# Altium schematic sheet INTERFACE.SchDoc
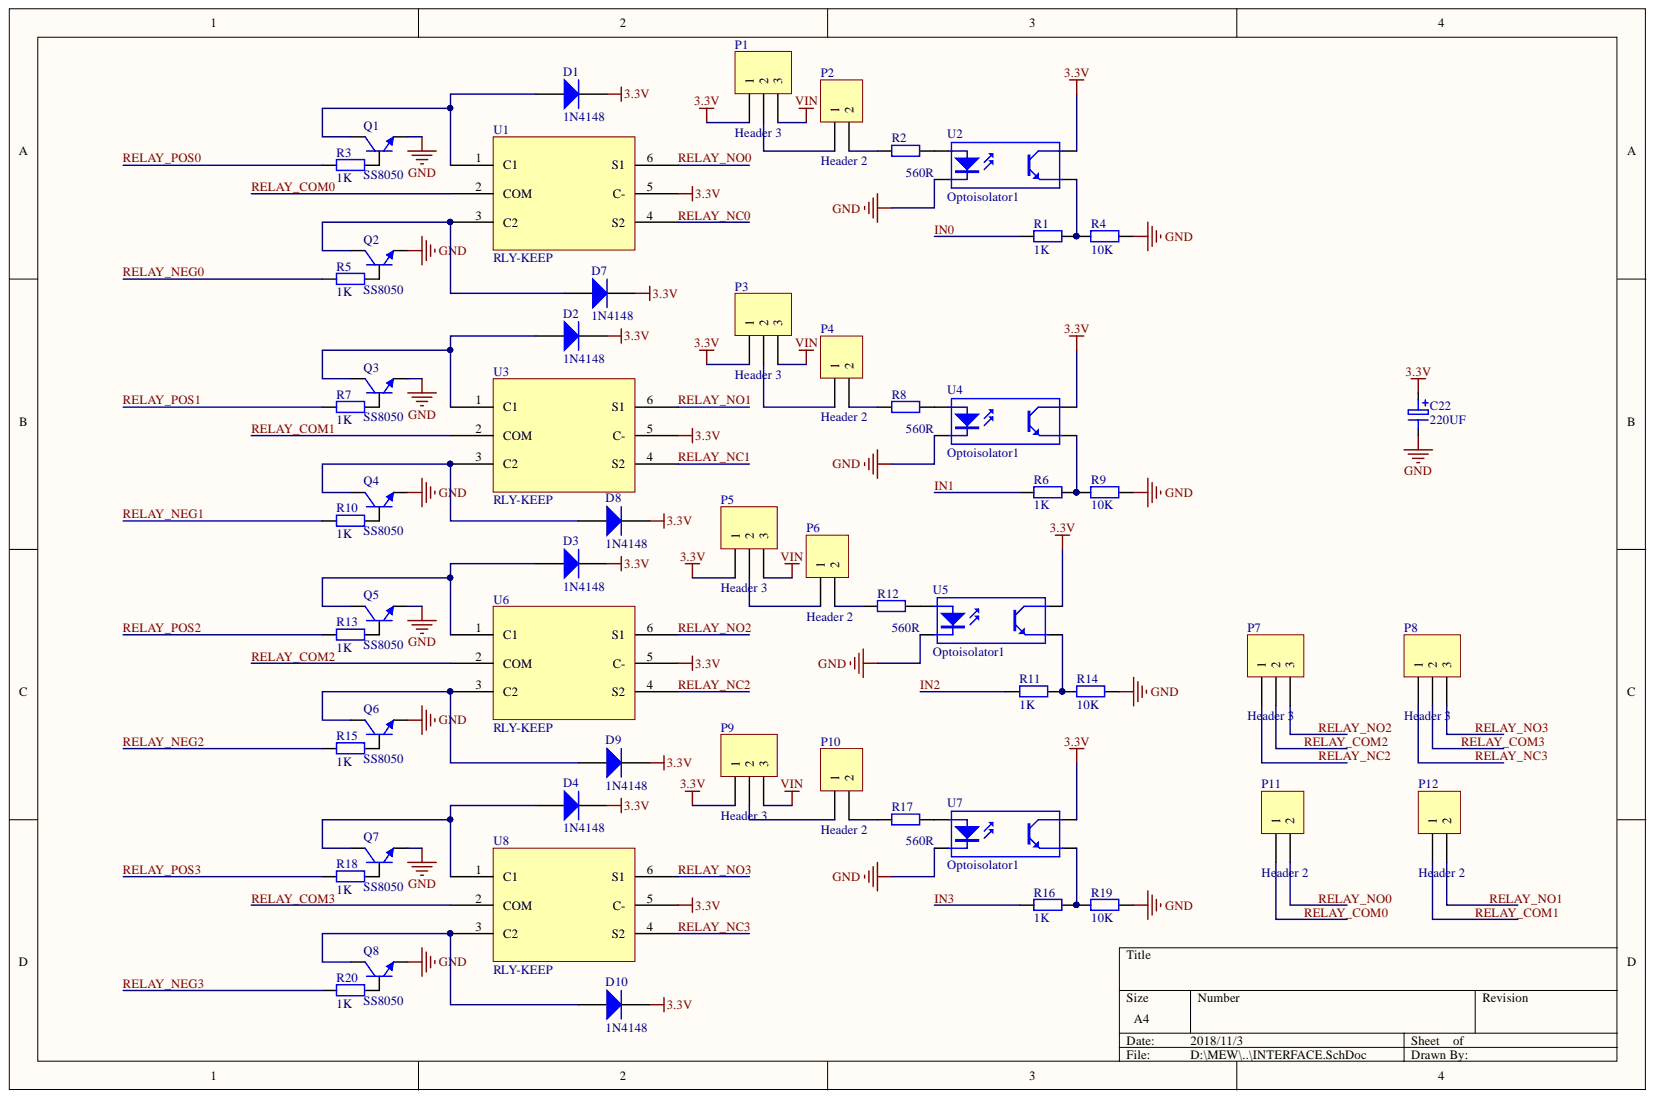
[diagram p0: INTERFACE.SchDoc]

## Parts
C22  220UF  [CAP-SMD-F6.3]
D1  1N4148  [D-1N4148]
D2  1N4148  [D-1N4148]
D3  1N4148  [D-1N4148]
D4  1N4148  [D-1N4148]
D7  1N4148  [D-1N4148]
D8  1N4148  [D-1N4148]
D9  1N4148  [D-1N4148]
D10  1N4148  [D-1N4148]
P1  Header 3  [HDR1X3]
P2  Header 2  [P-2-5.08]
P3  Header 3  [HDR1X3]
P4  Header 2  [P-2-5.08]
P5  Header 3  [HDR1X3]
P6  Header 2  [P-2-5.08]
P7  Header 3  [P-3-5.08]
P8  Header 3  [P-3-5.08]
P9  Header 3  [HDR1X3]
P10  Header 2  [P-2-5.08]
P11  Header 2  [P-2-5.08]
P12  Header 2  [P-2-5.08]
Q1  SS8050  [Q-8050(Y1)]
Q2  SS8050  [Q-8050(Y1)]
Q3  SS8050  [Q-8050(Y1)]
Q4  SS8050  [Q-8050(Y1)]
Q5  SS8050  [Q-8050(Y1)]
Q6  SS8050  [Q-8050(Y1)]
Q7  SS8050  [Q-8050(Y1)]
Q8  SS8050  [Q-8050(Y1)]
R1  1K  [RC0603]
R2  560R  [RC0603]
R3  1K  [RC0603]
R4  10K  [RC0603]
R5  1K  [RC0603]
R6  1K  [RC0603]
R7  1K  [RC0603]
R8  560R  [RC0603]
R9  10K  [RC0603]
R10  1K  [RC0603]
R11  1K  [RC0603]
R12  560R  [RC0603]
R13  1K  [RC0603]
R14  10K  [RC0603]
R15  1K  [RC0603]
R16  1K  [RC0603]
R17  560R  [RC0603]
R18  1K  [RC0603]
R19  10K  [RC0603]
R20  1K  [RC0603]
U1  RLY-KEEP  [RELAY-KEEP]
U2  Optoisolator1  [TLP181]
U3  RLY-KEEP  [RELAY-KEEP]
U4  Optoisolator1  [TLP181]
U5  Optoisolator1  [TLP181]
U6  RLY-KEEP  [RELAY-KEEP]
U7  Optoisolator1  [TLP181]
U8  RLY-KEEP  [RELAY-KEEP]

## Nets
3.3V: C22.1 D1.2 D2.2 D3.2 D4.2 D7.2 D8.2 D9.2 D10.2 P1.1 P3.1 P5.1 P9.1 U1.5(C-) U2.4 U3.5(C-) U4.4 U5.4 U6.5(C-) U7.4 U8.5(C-)
GND: C22.2 Q1.2 Q2.2 Q3.2 Q4.2 Q5.2 Q6.2 Q7.2 Q8.2 R4.2 R9.2 R14.2 R19.2 U2.2 U4.2 U5.2 U7.2
VIN: P1.3 P3.3 P5.3 P9.3
IN0: R1.2
IN1: R6.2
IN2: R11.2
IN3: R16.2
RELAY_COM0: P11.1 U1.2(COM)
RELAY_COM1: P12.1 U3.2(COM)
RELAY_COM2: P7.2 U6.2(COM)
RELAY_COM3: P8.2 U8.2(COM)
RELAY_NC0: U1.4(S2)
RELAY_NC1: U3.4(S2)
RELAY_NC2: P7.1 U6.4(S2)
RELAY_NC3: P8.1 U8.4(S2)
RELAY_NEG0: R5.1
RELAY_NEG1: R10.1
RELAY_NEG2: R15.1
RELAY_NEG3: R20.1
RELAY_NO0: P11.2 U1.6(S1)
RELAY_NO1: P12.2 U3.6(S1)
RELAY_NO2: P7.3 U6.6(S1)
RELAY_NO3: P8.3 U8.6(S1)
RELAY_POS0: R3.1
RELAY_POS1: R7.1
RELAY_POS2: R13.1
RELAY_POS3: R18.1
NetD1_1: D1.1 Q1.3 U1.1(C1)
NetD2_1: D2.1 Q3.3 U3.1(C1)
NetD3_1: D3.1 Q5.3 U6.1(C1)
NetD4_1: D4.1 Q7.3 U8.1(C1)
NetD7_1: D7.1 Q2.3 U1.3(C2)
NetD8_1: D8.1 Q4.3 U3.3(C2)
NetD9_1: D9.1 Q6.3 U6.3(C2)
NetD10_1: D10.1 Q8.3 U8.3(C2)
NetP1_2: P1.2 P2.1
NetP2_2: P2.2 R2.1
NetP3_2: P3.2 P4.1
NetP4_2: P4.2 R8.1
NetP5_2: P5.2 P6.1
NetP6_2: P6.2 R12.1
NetP9_2: P9.2 P10.1
NetP10_2: P10.2 R17.1
NetQ1_1: Q1.1 R3.2
NetQ2_1: Q2.1 R5.2
NetQ3_1: Q3.1 R7.2
NetQ4_1: Q4.1 R10.2
NetQ5_1: Q5.1 R13.2
NetQ6_1: Q6.1 R15.2
NetQ7_1: Q7.1 R18.2
NetQ8_1: Q8.1 R20.2
NetR1_1: R1.1 R4.1 U2.3
NetR2_2: R2.2 U2.1
NetR6_1: R6.1 R9.1 U4.3
NetR8_2: R8.2 U4.1
NetR11_1: R11.1 R14.1 U5.3
NetR12_2: R12.2 U5.1
NetR16_1: R16.1 R19.1 U7.3
NetR17_2: R17.2 U7.1

## Library data of the parts
C22 = CAP-aluminum: Component Kind: Standard; Library Name: HFNADLIB.SchLib
D1-D4 D7-D10 = Diode: Default Diode; Code_JEDEC: DO-214-AB; Component Kind: Standard; LatestRevisionDate: 17-Jul-2002; LatestRevisionNote: Re-released for DXP Platform.; Library Name: Miscellaneous Devices.IntLib; PackageDescription: DO-214-AB/SMC; 2 C-Bend Leads; Body 7.9 x 5.9 mm, inc. leads (LxW); PackageReference: SMC; PackageVersion: Sep-1996; Published: 8-Jun-2000; Signal Integrity: Diode; Simulation: DIODE; Publisher: Altium Limited
P1 P3 P5 P7-P9 = Header 3: Header, 3-Pin; Component Kind: Standard; LatestRevisionDate: 17-Jul-2002; LatestRevisionNote: Re-released for DXP Platform.; Library Name: Miscellaneous Connectors.IntLib; Publisher: Altium Limited
  P1 P3 P5 P9: Signal Integrity: Connector
P2 P4 P6 P10-P12 = Header 2: Header, 2-Pin; Component Kind: Standard; LatestRevisionDate: 17-Jul-2002; LatestRevisionNote: Re-released for DXP Platform.; Library Name: Miscellaneous Connectors.IntLib; Signal Integrity: Connector; Publisher: Altium Limited
Q1-Q8 = Q-NPN: Component Kind: Standard; Library Name: HFNADLIB.SchLib
R1-R20 = RES: Component Kind: Standard; Library Name: HFNADLIB.SchLib
U1 U3 U6 U8 = RELAY-KEEP: Component Kind: Standard; Library Name: HFNADLIB.SchLib
U2 U4 U5 U7 = Optoisolator1: 4-Pin Phototransistor Optocoupler; Component Kind: Standard; DatasheetVersion: Nov-2003; LatestRevisionDate: 04-Mar-2005; LatestRevisionNote: Sch pins 3 & 4 Swapped.; Library Name: Miscellaneous Devices.IntLib; PackageReference: DIP-4; Published: 24-Mar-1999; Publisher: Altium Limited
